# Altium schematic sheet Sheet3.SchDoc(Sheet3)
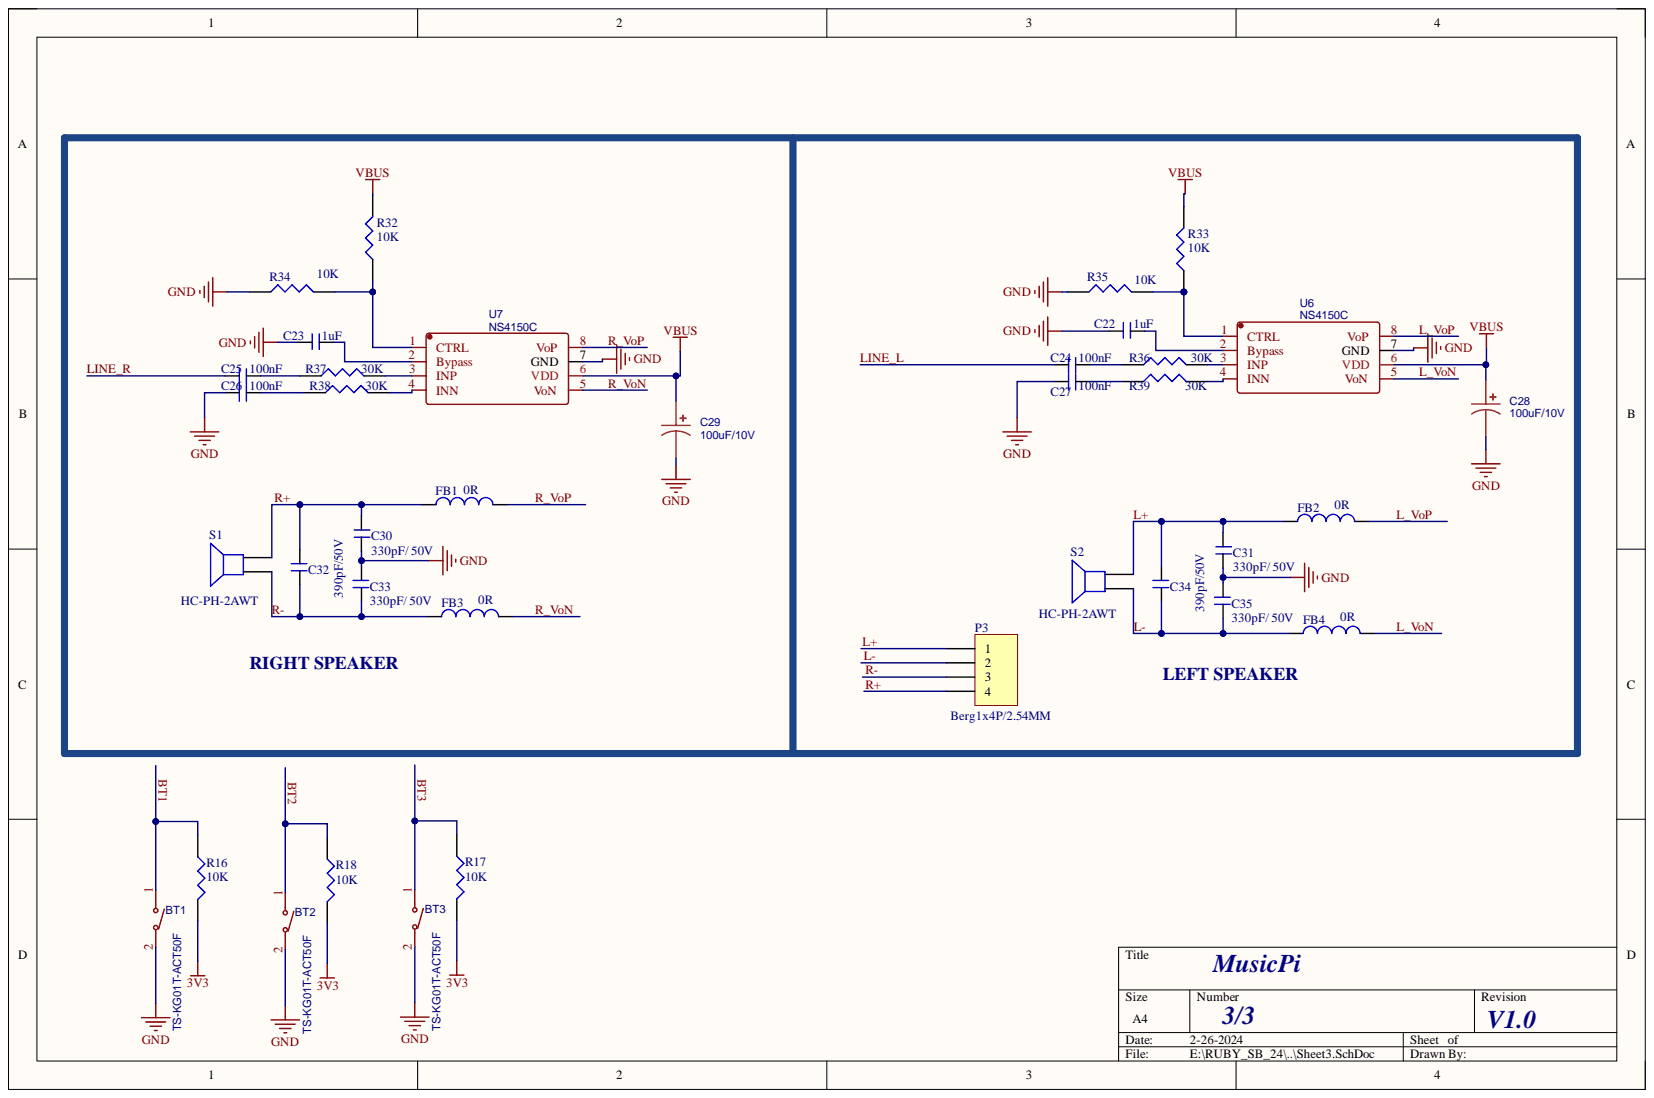
[diagram p0: Sheet3.SchDoc]

## Parts
BT1  TS-KG01T-ACT50F  [SW_2P SMD]
BT2  TS-KG01T-ACT50F  [SW_2P SMD]
BT3  TS-KG01T-ACT50F  [SW_2P SMD]
C22  1uF  [C_0603]
C23  1uF  [C_0603]
C24  100nF  [C_0603]
C25  100nF  [C_0603]
C26  100nF  [C_0603]
C27  100nF  [C_0603]
C28  100uF/10V  [CASE-B-3528]
C29  100uF/10V  [CASE-B-3528]
C30  330pF/ 50V  [C_0603]
C31  330pF/ 50V  [C_0603]
C32  390pF/50V  [C_0603]
C33  330pF/ 50V  [C_0603]
C34  390pF/50V  [C_0603]
C35  330pF/ 50V  [C_0603]
FB1  FB  value 0R  [R_0603]
FB2  FB  value 0R  [R_0603]
FB3  FB  value 0R  [R_0603]
FB4  FB  value 0R  [R_0603]
P3  Berg1x4P/2.54MM  [4P_TH_2.54MM]
R16  10K  [R_0603]
R17  10K  [R_0603]
R18  10K  [R_0603]
R32  10K  [R_0603]
R33  10K  [R_0603]
R34  10K  [R_0603]
R35  10K  [R_0603]
R36  30K  [R_0603]
R37  30K  [R_0603]
R38  30K  [R_0603]
R39  30K  [R_0603]
S1  HC-PH-2AWT  [JST2P_P2.0mm]
S2  HC-PH-2AWT  [JST2P_P2.0mm]
U6  NS4150C  [SOP 8P]
U7  NS4150C  [SOP 8P]

## Nets
3V3: R16.2 R17.2 R18.2
GND: BT1.2 BT2.2 BT3.2 C22.1 C23.1 C26.2 C27.2 C28.2 C29.2 C30.2 C31.2 C33.2 C35.2 R34.1 R35.1 U6.7(GND) U7.7(GND)
VBUS: C28.1 C29.1 R32.2 R33.2 U6.6(VDD) U7.6(VDD)
BT1: BT1.1 R16.1
BT2: BT2.1 R18.1
BT3: BT3.1 R17.1
L+: C31.1 C34.2 FB2.1 P3.1 S2.2
L-: C34.1 C35.1 FB4.1 P3.2 S2.1
L_VoN: FB4.2 U6.5(VoN)
L_VoP: FB2.2 U6.8(VoP)
LINE_L: C24.2
LINE_R: C25.2
R+: C30.1 C32.2 FB1.1 P3.4 S1.2
R-: C32.1 C33.1 FB3.1 P3.3 S1.1
R_VoN: FB3.2 U7.5(VoN)
R_VoP: FB1.2 U7.8(VoP)
NetC22_2: C22.2 U6.2(Bypass)
NetC23_2: C23.2 U7.2(Bypass)
NetC24_1: C24.1 R36.1
NetC25_1: C25.1 R37.1
NetC26_1: C26.1 R38.1
NetC27_1: C27.1 R39.1
NetR32_1: R32.1 R34.2 U7.1(CTRL)
NetR33_1: R33.1 R35.2 U6.1(CTRL)
NetR36_2: R36.2 U6.3(INP)
NetR37_2: R37.2 U7.3(INP)
NetR38_2: R38.2 U7.4(INN)
NetR39_2: R39.2 U6.4(INN)

## Library data of the parts
BT1-BT3 = TS-KG01T-ACT50F: BOM_Manufacturer: HANBO(汉博); Contributor: LCSC; BOM_JLCPCB Part Class: Extended Part; BOM_Manufacturer Part: TS-KG01T-ACT50F; BOM_Supplier: LCSC; BOM_Supplier Part: C2874211; Component Kind: Standard; spicePre: S; spiceSymbolName: TS-KG01T-ACT50F
C22-C27 C30-C35 = CAP_NONPOLAR: Component Kind: Standard; Library Name: dxp_pasl_lib.IntLib
C28 C29 = 270uF: BOM_Manufacturer: rubycon(红宝石); BOM_Manufacturer Part: 100ZL270MEFC12.5X35; Contributor: lcsc; nameAlias: Capacitance; BOM_JLCPCB Part Class: Extended Part; BOM_Supplier: LCSC; BOM_Supplier Part: C1598036; Component Kind: Standard; link: https://atta.szlcsc.com/upload/public/pdf/source/20210810/D32E969E718DCFB364F518B2A745C406.pdf; spicePre: C; spiceSymbolName: 100ZL270MEFC12.5X35; Library Name: MIX.SchLib
FB1-FB4 = Inductor: Code_IEC: 1005; Code_JEITA: 0402; Component Kind: Standard; Description: Inductor; LatestRevisionDate: 29-May-2009; LatestRevisionNote: IPC-7351 Footprint Added.; Library Name: Miscellaneous Devices.IntLib; PackageDescription: Chip Inductor; PackageReference: 0402-A; Published: 8-Jun-2000; Signal Integrity: Inductor; Simulation: INDUCTOR; Publisher: Altium Limited
P3 = Header 4: Header, 4-Pin; Component Kind: Standard; LatestRevisionDate: 17-Jul-2002; LatestRevisionNote: Re-released for DXP Platform.; Library Name: Miscellaneous Connectors.IntLib; Signal Integrity: Connector; Publisher: Altium Limited
R16-R18 R32-R39 = RES: Component Kind: Standard; Library Name: dxp_pasl_lib.IntLib
S1 S2 = Speaker: Loudspeaker; Component Kind: Standard; LatestRevisionDate: 17-Jul-2002; LatestRevisionNote: Re-released for DXP Platform.; Library Name: Miscellaneous Devices.IntLib; PackageReference: PIN2; Published: 24-Mar-1999; Publisher: Altium Limited
U6 U7 = NS4150C: Manufacturer: Nsiway(纳芯威); Contributor: LCSC; Component Kind: Standard; JLCPCB Part Class: Extended Part; Manufacturer Part: NS4150C; spicePre: U; spiceSymbolName: NS4150C; Supplier: LCSC; Supplier Part: C2875838
